# Altium schematic sheet XYAmp.SchDoc(XYAmp)
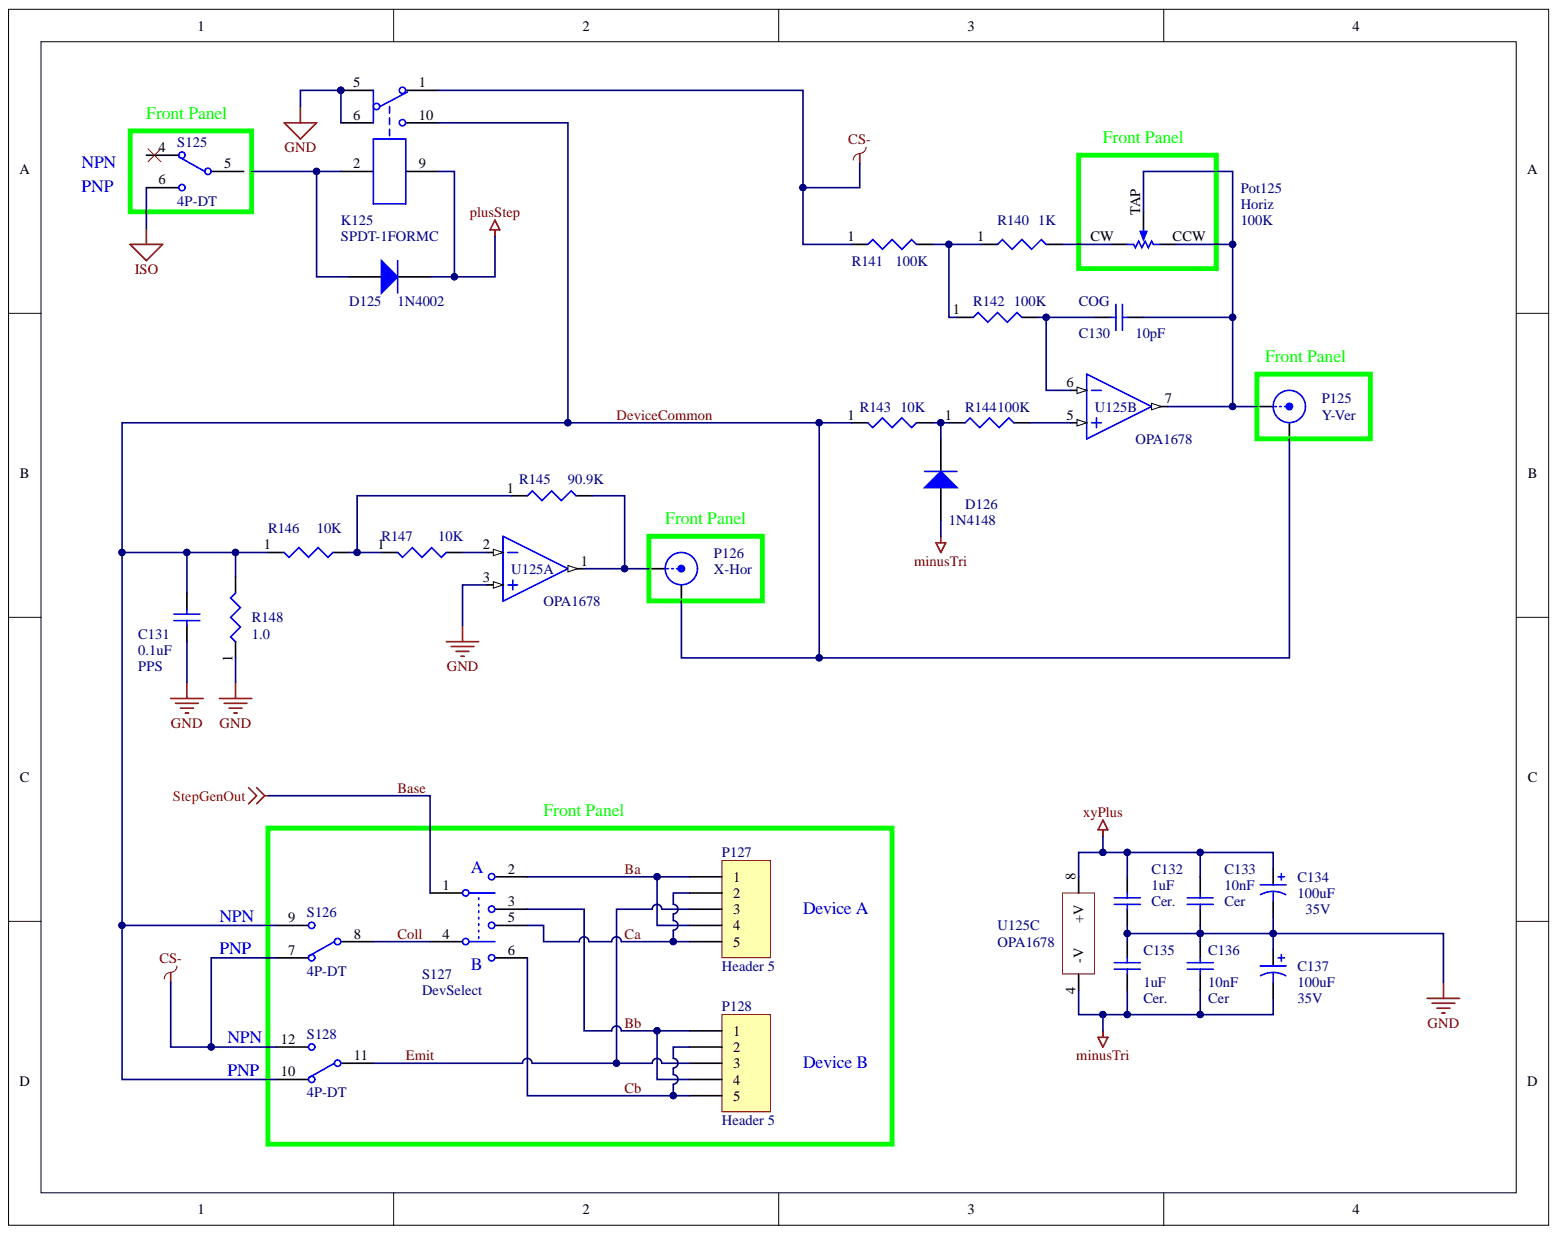
[diagram p0: XYAmp.SchDoc]

## Parts
C130  value 10pF  Temperature Coefficient: COG  [CAPC3216M]  (hidden comment: Cap)
C131  value 0.1uF  Dielectric: PPS  [CAPC3225M]  (hidden comment: Cap)
C132  value 1uF  Dielectric Material: Cer.  [CAPC3216M]  (hidden comment: Cap)
C133  10nF  Dielectric Material: Cer  [CAPC3216M]
C134  100uF  Voltage Rating: 35V  [CAPPR2.5-6.3X10]
C135  value 1uF  Dielectric Material: Cer.  [CAPC3216M]  (hidden comment: Cap)
C136  10nF  Dielectric Material: Cer  [CAPC3216M]
C137  100uF  Voltage Rating: 35V  [CAPPR2.5-6.3X10]
D125  1N4002  [SMA-D]
D126  1N4148  [SOD123]
K125  SPDT-1FORMC  [1FORMC-OMR]
P125  Y-Ver  [BNC_RA CON]
P126  X-Hor  [BNC_RA CON]
P127  Header 5  [5-2.5MMLS]
P128  Header 5  [5-2.5MMLS]
Pot125  Horiz  value 100K  [Off-PCB]
R140  1K  [RESC3216M]
R141  100k  [RESC3216M]
R142  100k  [RESC3216M]
R143  10K  [RESC3216M]
R144  100k  [RESC3216M]
R145  90.9K  [RESC3216M]
R146  10K  [RESC3216M]
R147  10K  [RESC3216M]
R148  1  value 1.0  [RESC6432BM]
S125  4P-DT  [3-2.5MMLS4-6]
S126  4P-DT  [3-2.5MMLS7-9]
S127  DevSelect  [6-2.5MMLS]
S128  4P-DT  [3-2.5MMLS10-12]
U125  OPA1678  [SOIC127P600X168_HS-8N]  (parts A,B,C)

## Nets
CS-: K125.1 R141.1 S126.7 S128.12
GND: C131.2 C132.1 C133.1 C134.2 C135.2 C136.2 C137.1 K125.5 K125.6 R148.1 U125.3
ISO: S125.6
minusTri: C135.1 C136.1 C137.2 D126.1 U125.4(-V)
plusStep: D125.2 K125.9
xyPlus: C132.2 C133.2 C134.1 U125.8(+V)
Ba: P127.1 P127.4 S127.2
Base: S127.1
Bb: P128.1 P128.4 S127.3
Ca: P127.2 P127.5 S127.5
Cb: P128.2 P128.5 S127.6(B)
Coll: S126.8 S127.4
DeviceCommon: C131.1 K125.10 P125.2 P126.2 R143.1 R146.1 R148.2 S126.9 S128.10
Emit: P127.3 P128.3 S128.11
NetC130_1: C130.1 R142.2 U125.6
NetC130_2: C130.2 P125.1 Pot125.CCW Pot125.TAP U125.7
NetD125_1: D125.1 K125.2
NetD126_2: D126.2 R143.2 R144.1
NetP126_1: P126.1 R145.2 U125.1
NetPot125_CW: Pot125.CW R140.2
NetR140_1: R140.1 R141.2 R142.1
NetR144_2: R144.2 U125.5
NetR145_1: R145.1 R146.2 R147.1
NetR147_2: R147.2 U125.2

Not connected: S125 4 5

## Library data of the parts
C130 = Cap: CAP CER 10PF 100V NP0 1206; Manufacturer Part Number: C1206C100J1GACTU; Manufacturer: Kemet; Tolerance: ±5%; Voltage - Rated: 100V; Thickness (Max): 0.035" (0.88mm); Supplier Part Number: 399-8124-1-ND; Supplier: Digi-Key; Size / Dimension: 0.126" L x 0.063" W (3.20mm x 1.60mm); Simulation: CAP; Signal Integrity: Cap; RoHS: RoHS Compliant; Packaging: Cut Tape (CT); Package / Case: 1206 (3216 Metric); Operating Temperature: -55°C ~ 125°C; Mounting Type: Surface Mount, MLCC; Library Name: Miscellaneous Devices.IntLib; Component Kind: Standard; Category: Capacitors; Capacitance: 10pF; Applications: General Purpose
C131 = Cap: CAP FILM 1UF 20% 16VDC 1210; Manufacturer Part Number: ECPU1C105MA5; Manufacturer: Panasonic; Voltage Rating (DC): 16V; Insulation Resistance: 300MR; Dissipation Factor: 1.5%; Width: 2.5mm; Voltage Rating: 16V; Voltage: 16V; Tolerance: 20%; Termination: SMD/SMT; Supplier Part Number: PCF1132CT-ND; Supplier: Digi-Key; Simulation: CAP; Signal Integrity: Cap; REACH SVHC: No SVHC; Radiation Hardening: No; Packaging: Tape and Reel; Package Quantity: 1; Number of Pins: 2; Mount: Surface Mount; Min Operating Temperature: -40°C; Max Operating Temperature: 85°C; Library Name: Miscellaneous Devices.IntLib; Length: 3.2mm; Lead Free: Lead Free; Height - Seated (Max): 0.063inch; Height: 1.4mm; Depth: 2.5mm; Contact Plating: Copper,Tin,Silver; Component Kind: Standard; Case Code (Metric): 3225; Case Code (Imperial): 1210; Case/Package: 1210; Capacitance: 1uF
C132 C135 = Cap: CAP CER 1UF 25V X7R 1206; Manufacturer Part Number: C1206C105M3RACTU; Manufacturer: Kemet; Tolerance: ±20%; Capacitance: 1µF; Voltage - Rated: 25V; Thickness (Max): 0.055" (1.40mm); Temperature Coefficient: X7R; Supplier Part Number: 399-8148-1-ND; Supplier: Digi-Key; Size / Dimension: 0.126" L x 0.063" W (3.20mm x 1.60mm); Simulation: CAP; Signal Integrity: Cap; RoHS: RoHS Compliant; Packaging: Cut Tape (CT); Package / Case: 1206 (3216 Metric); Operating Temperature: -55°C ~ 125°C; Mounting Type: Surface Mount, MLCC; Library Name: Miscellaneous Devices.IntLib; Component Kind: Standard; Category: Capacitors; Applications: General Purpose
C133 C136 = Cap: CAP CER 1UF 25V X7R 1206; Manufacturer Part Number: C1206C103K3RACTU; Manufacturer: KEMET; Tolerance: 10%; Width: 1.6mm; Voltage Rating (DC): 25V; Voltage Rating: 25V; Value: 10nF; Thickness: 0.035inch; Termination: SMD/SMT; Supplier Part Number: 399-14644-1-ND; Supplier: Digi-Key; Simulation: CAP; Signal Integrity: Cap; Radiation Hardening: No; Packaging: Tape and Reel; Package Quantity: 4000; Mount: Surface Mount; Min Operating Temperature: -55°C; Max Operating Temperature: 125°C; Library Name: Miscellaneous Devices.IntLib; Length: 3.2mm; Height: 0.78mm; Dielectric: X7R; Depth: 1.6mm; Component Kind: Standard; Case Code (Metric): 3216; Case Code (Imperial): 1206; Case/Package: 1206; Capacitance: 10nF
C134 C137 = Cap Pol1: CAP ALUM 100UF 20% 35V THRU HOLE; Manufacturer Part Number: 35YXJ100M6.3X11; Manufacturer: Rubycon; Ripple Current: 400mA; Polarity: Polar; Life (Hours): 7000Hour; Leakage Current: 3uA; Lead Diameter: 0.5mm; Impedance: 220mR; Voltage: 35V; Value: 100uF; Tolerance: 20%; Termination: Radial; Supplier Part Number: 1189-2260-ND; Supplier: Digi-Key; Simulation: CAP; Signal Integrity: Cap Pol; Radiation Hardening: No; Packaging: Bulk; Package Quantity: 3000; Mount: Through Hole; Min Operating Temperature: -40°C; Max Operating Temperature: 105°C; Library Name: Miscellaneous Devices.IntLib; Lead Pitch: 2.5mm; Height - Seated (Max): 0.492inch; Height: 11mm; Diameter: 6.3mm; Component Kind: Standard; Case/Package: Radial; Capacitance: 100uF
D125 = Diode 1N4002: 1 Amp General Purpose Rectifier; Simulation: 1N4002; Signal Integrity: 1N4002; Published: 8-Jun-2000; PackageVersion: Aug-1999; PackageReference: DO-41; PackageDescription: Diode, Axial; Body 5.2 x 2.7 mm (LxDia. max), Lead Dia. 0.86 mm (max); Library Name: Miscellaneous Devices.IntLib; LatestRevisionNote: Re-released for DXP Platform.; LatestRevisionDate: 17-Jul-2002; DatasheetVersion: 1998; ComponentLink2URL: http://www.fairchildsemi.com/ds/1N%2F1N4001.pdf; ComponentLink2Description: Datasheet; ComponentLink1URL: http://www.fairchildsemi.com/; ComponentLink1Description: Manufacturer Link; Component Kind: Standard; Code_JEDEC: DO-204-AL; Publisher: Altium Limited
D126 = Diode 1N4148: DIODE GP 75V 150MA SOD123FL; Manufacturer Part Number: 1N4148WFL-G3-08; Manufacturer: Vishay Semiconductor Diodes Division; Voltage - Forward (Vf) (Max) @ If: 1.2V @ 100mA; Speed: Small Signal =< 200mA (Io), Any Speed; Reverse Recovery Time (trr): 4ns; Diode Type: Standard; Current - Reverse Leakage @ Vr: 5µA @ 75V; Voltage - DC Reverse (Vr) (Max): 75V; Supplier Part Number: 1N4148WFL-G3-08GICT-ND; Supplier Device Package: SOD-123FL; Supplier: Digi-Key; Simulation: 1N4148; Signal Integrity: 1N4148; RoHS: RoHS Compliant; Published: 8-Jun-2000; Packaging: Cut Tape (CT); PackageReference: DO-35; PackageDescription: Diode, Axial; Body 3.8 x 1.9 mm (LxDia. max), Lead Dia. 0.53 mm (max); Package / Case: SOD-123F; Operating Temperature - Junction: 150°C (Max); Mounting Type: Surface Mount; Library Name: Miscellaneous Devices.IntLib; LatestRevisionNote: Re-released for DXP Platform.; LatestRevisionDate: 17-Jul-2002; DatasheetVersion: 1997; Current - Average Rectified (Io): 150mA; ComponentLink2URL: http://www.fairchildsemi.com/ds/1N%2F1N4148.pdf; ComponentLink2Description: Datasheet; ComponentLink1URL: http://www.fairchildsemi.com/; ComponentLink1Description: Manufacturer Link; Component Kind: Standard; Code_JEDEC: DO-204-AH; Category: Discrete Semiconductor Products; Publisher: Altium Limited
K125 = SPDT-1FORMC: RELAY GEN PURPOSE SPDT 1A 125V; Manufacturer Part Number: G5V-1-DC12; Manufacturer: Omron; Voltage Rating (DC): 60V; Release Time: 5ms; Relay Type: General Purpose; Power Rating: 150mW; Coil Voltage (DC): 12V; Coil Type: Non-Latching; Coil Resistance: 960R; Coil Current: 12.5mA; Approvals: UL,CSA; Width: 7.5mm; Weight: 2g; Voltage Rating (AC): 125V; Type: Electromechanical; Throw Configuration: SPDT; Termination: PC Pin; Supplier Part Number: Z774-ND; Supplier: Digi-Key; REACH SVHC: No SVHC; Radiation Hardening: No; Power Consumption: 150mW; Packaging: Rail/Tube; Package Quantity: 500; Operate Time: 5ms; Number of Pins: 6; Mount: Through Hole,PCB; Min Operating Temperature: -40°C; Max Voltage Rating (DC): 60V; Max Voltage Rating (AC): 125V; Max Operating Temperature: 70°C; Max Current Rating: 1A; Library Name: My_Relays.SchLib; Length: 12.5mm; Lead Free: Lead Free; Height: 10mm; Depth: 7.5mm; Current Rating: 1A; Contact Material: Gold,Silver; Contact Current Rating: 1A; Component Kind: Standard; Coil Power: 150mW; Case/Package: DIP
P125 P126 = BNC: BNC Elbow Connector; Signal Integrity: Connector; Library Name: Miscellaneous Connectors.IntLib; LatestRevisionNote: Re-released for DXP Platform.; LatestRevisionDate: 17-Jul-2002; Component Kind: Standard; Publisher: Altium Limited
P127 P128 = Header 5: Header, 5-Pin; Signal Integrity: Connector; Library Name: Miscellaneous Connectors.IntLib; LatestRevisionNote: Re-released for DXP Platform.; LatestRevisionDate: 17-Jul-2002; Component Kind: Standard; Publisher: Altium Limited
Pot125 = POT-Single: TRIMMER 1K OHM 0.5W PC PIN TOP; Manufacturer Part Number: PV36W102C01B00; Manufacturer: Bourns; Tolerance: 10%; Temperature Coefficient: 100ppm/°C; Resistance: 1kR; Number of Turns: 25; Max Power Dissipation: 500mW; Termination: PC Pins; Supplier Part Number: 490-2874-ND; Supplier: Digi-Key; Packaging: Bulk; Mount: Through Hole; Height: 0.394inch; Composition: Cermet; Component Kind: Standard; Library Name: MY_POTS.SchLib
R140 = Res3: RES 1K OHM 1% 1/4W 1206; Manufacturer Part Number: RMCF1206FT1K00; Manufacturer: Stackpole Electronics; Temperature Coefficient: 100ppm/°C; Resistance: 1kR; Power Rating: 250mW; Width: 0.063inch; Value: 1K; Tolerance: 1%; Supplier Part Number: RMCF1206FT1K00CT-ND; Supplier: Arrow; Simulation: RESISTOR; Signal Integrity: Res; Radiation Hardening: No; Packaging: Tape and Reel; Number of Terminations: 2; Mount: Surface Mount; Min Operating Temperature: -55°C; Military Standard: Not; Max Power Dissipation: 250mW; Max Operating Temperature: 155°C; Library Name: Miscellaneous Devices.IntLib; Length: 3.2mm; Lead Free: Lead Free; Height: 0.028inch; Features: Automotive AEC-Q200; Depth: 1.6mm; Contact Plating: Matte,Tin; Composition: Thick Film; Component Kind: Standard; Case Code (Metric): 3216; Case Code (Imperial): 1206; Case/Package: 1206
R141 R142 R144 = Res3: RES 100K OHM 1% 1/4W 1206; Manufacturer Part Number: RMCF1206FT100K; Manufacturer: Stackpole Electronics; Temperature Coefficient: 100ppm/°C; Resistance: 100kR; Power Rating: 250mW; Width: 0.063inch; Value: 100K; Tolerance: 1%; Supplier Part Number: RMCF1206FT100KCT-ND; Supplier: Digi-Key; Simulation: RESISTOR; Signal Integrity: Res; Radiation Hardening: No; Packaging: Tape and Reel; Number of Terminations: 2; Mount: Surface Mount; Min Operating Temperature: -55°C; Military Standard: Not; Max Power Dissipation: 250mW; Max Operating Temperature: 155°C; Library Name: Miscellaneous Devices.IntLib; Length: 0.126inch; Lead Free: Lead Free; Height: 0.028inch; Features: Automotive AEC-Q200; Depth: 1.6mm; Contact Plating: Matte,Tin; Composition: Thick Film; Component Kind: Standard; Case Code (Metric): 3216; Case Code (Imperial): 1206; Case/Package: 1206
R143 R146 R147 = Res3: RES 10K OHM 1% 1/4W 1206; Manufacturer Part Number: RMCF1206FT10K0; Manufacturer: Stackpole Electronics; Voltage Rating (DC): 200V; Temperature Coefficient: 100ppm/°C; Resistance: 10kR; Power Rating: 250mW; Width: 0.063inch; Voltage Rating: 200V; Value: 10K; Tolerance: 1%; Termination: SMD/SMT; Supplier Part Number: RMCF1206FT10K0CT-ND; Supplier: Digi-Key; Simulation: RESISTOR; Signal Integrity: Res; REACH SVHC: No SVHC; Radiation Hardening: No; Packaging: Tape and Reel; Package Quantity: 1; Number of Terminations: 2; Number of Pins: 2; Mount: Surface Mount; Min Operating Temperature: -55°C; Military Standard: Not; Max Power Dissipation: 250mW; Max Operating Temperature: 155°C; Library Name: Miscellaneous Devices.IntLib; Length: 3.2mm; Lead Free: Lead Free; Height: 0.028inch; Features: Automotive AEC-Q200; Depth: 1.6mm; Contact Plating: Matte,Tin; Composition: Thick Film; Component Kind: Standard; Case Code (Metric): 3216; Case Code (Imperial): 1206; Case/Package: 1206
R145 = Res3: RES 90.9K OHM 1% 1/4W 1206; Manufacturer Part Number: RMCF1206FT90K9; Manufacturer: Stackpole Electronics; Temperature Coefficient: 100ppm/°C; Resistance: 90.9KR; Power Rating: 250mW; Width: 0.063inch; Value: 90.9K; Tolerance: 1%; Supplier Part Number: RMCF1206FT49K9CT-ND; Supplier: Digi-Key; Simulation: RESISTOR; Signal Integrity: Res; Radiation Hardening: No; Packaging: Tape and Reel; Number of Terminations: 2; Mount: Surface Mount; Min Operating Temperature: -55°C; Military Standard: Not; Max Power Dissipation: 250mW; Max Operating Temperature: 155°C; Library Name: Miscellaneous Devices.IntLib; Length: 0.126inch; Lead Free: Lead Free; Height: 0.028inch; Features: Automotive AEC-Q200; Depth: 1.6mm; Contact Plating: Matte,Tin; Composition: Thick Film; Component Kind: Standard; Case Code (Metric): 3216; Case Code (Imperial): 1206; Case/Package: 1206
R148 = Res3: RES 1` OHM 1% 1/4W 1206; Manufacturer Part Number: RMCF1206FT1R00; Manufacturer: Stackpole Electronics; Temperature Coefficient: 200ppm/°C; Resistance: 1R; Power Rating: 250mW; Width: 0.063inch; Tolerance: 1%; Supplier Part Number: RMCF1206FT1R00CT-ND; Supplier: Digi-Key; Simulation: RESISTOR; Signal Integrity: Res; Radiation Hardening: No; Packaging: Tape and Reel; Number of Terminations: 2; Mount: Surface Mount; Min Operating Temperature: -55°C; Military Standard: Not; Max Power Dissipation: 250mW; Max Operating Temperature: 155°C; Library Name: Miscellaneous Devices.IntLib; Length: 3.2mm; Lead Free: Lead Free; Height: 0.028inch; Features: Automotive AEC-Q200; Depth: 1.6mm; Contact Plating: Tin,Matte; Composition: Thick Film; Component Kind: Standard; Case Code (Metric): 3216; Case Code (Imperial): 1206; Case/Package: 1206
S125 S126 S128 = SW-SPDT: SPDT Subminiature Toggle Switch, Right Angle Mounting, Vertical Actuation; Published: 24-Mar-1999; PackageReference: TL36WW15050; Library Name: My_Miscellaneous Devices.IntLib; LatestRevisionNote: Schematic revised, PCB Footprint replaced.; LatestRevisionDate: 17-Dec-2003; DatasheetVersion: 05-Dec-2000; ComponentLink1URL: http://www.apem.com; ComponentLink1Description: Manufacturer Link; Component Kind: Standard; Publisher: Altium Limited
S127 = SW-DPDT: Double-Pole, Double-Throw Switch; Published: 24-Mar-1999; PackageReference: DPDT-6; Library Name: My_Miscellaneous Devices.IntLib; LatestRevisionNote: Re-released for DXP Platform.; LatestRevisionDate: 17-Jul-2002; Component Kind: Standard; Publisher: Altium Limited
U125 = Dual OP Amp separte supplies: IC AUDIO AMP 2 CIRCUIT 8VSSOP; Manufacturer Part Number: OPA1678IDGKT; Manufacturer: Texas Instruments; Slew Rate: 9V/µs; Operating Supply Current: 2mA; Number of Circuits: 2; Input Offset Voltage (Vos): 500uV; Input Bias Current: 10pA; Gain Bandwidth Product: 16MHz; Value: OPA1678; Supplier Part Number: 296-46561-2-ND; Supplier: Digi-Key; Packaging: Tape and Reel; Number of Channels: 2; Mount: Surface Mount; Min Operating Temperature: -40°C; Max Operating Temperature: 85°C; Library Name: My_OpAmps.SchLib; Component Kind: Standard; Case/Package: VSSOP
